# Altium schematic sheet GPS_GNSS.SchDoc(GPS_GNSS)
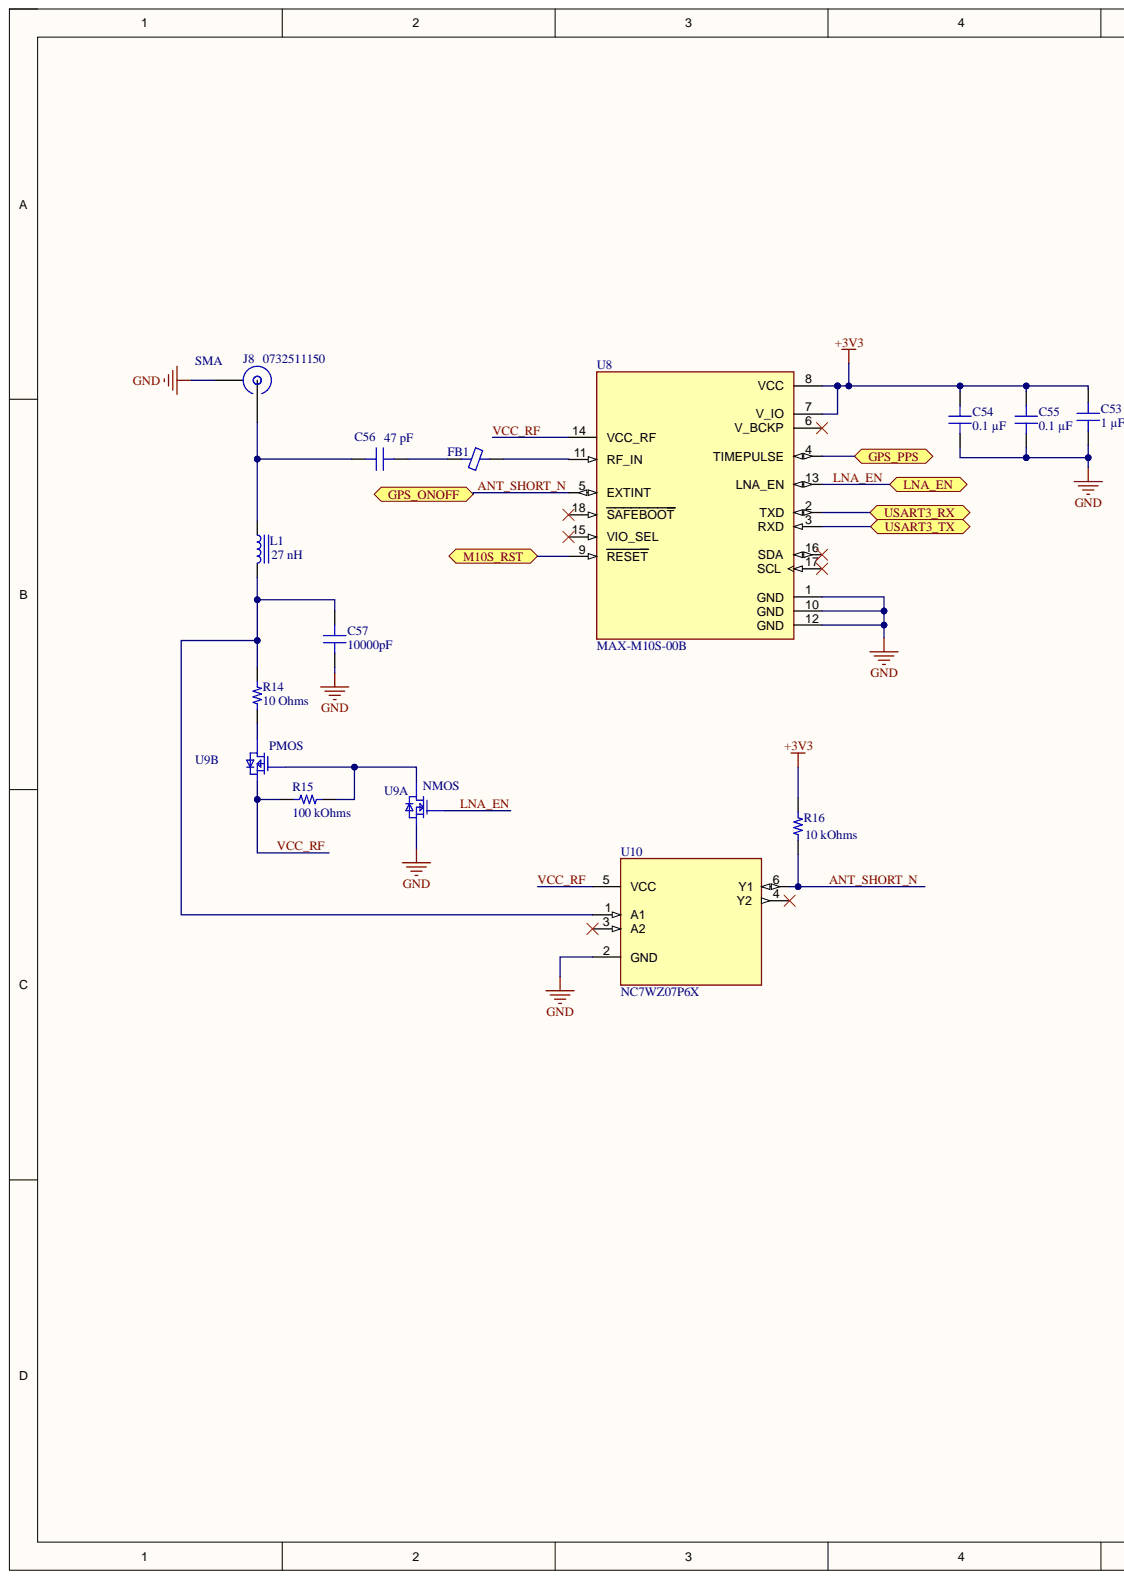
[diagram p0: GPS_GNSS.SchDoc, left]
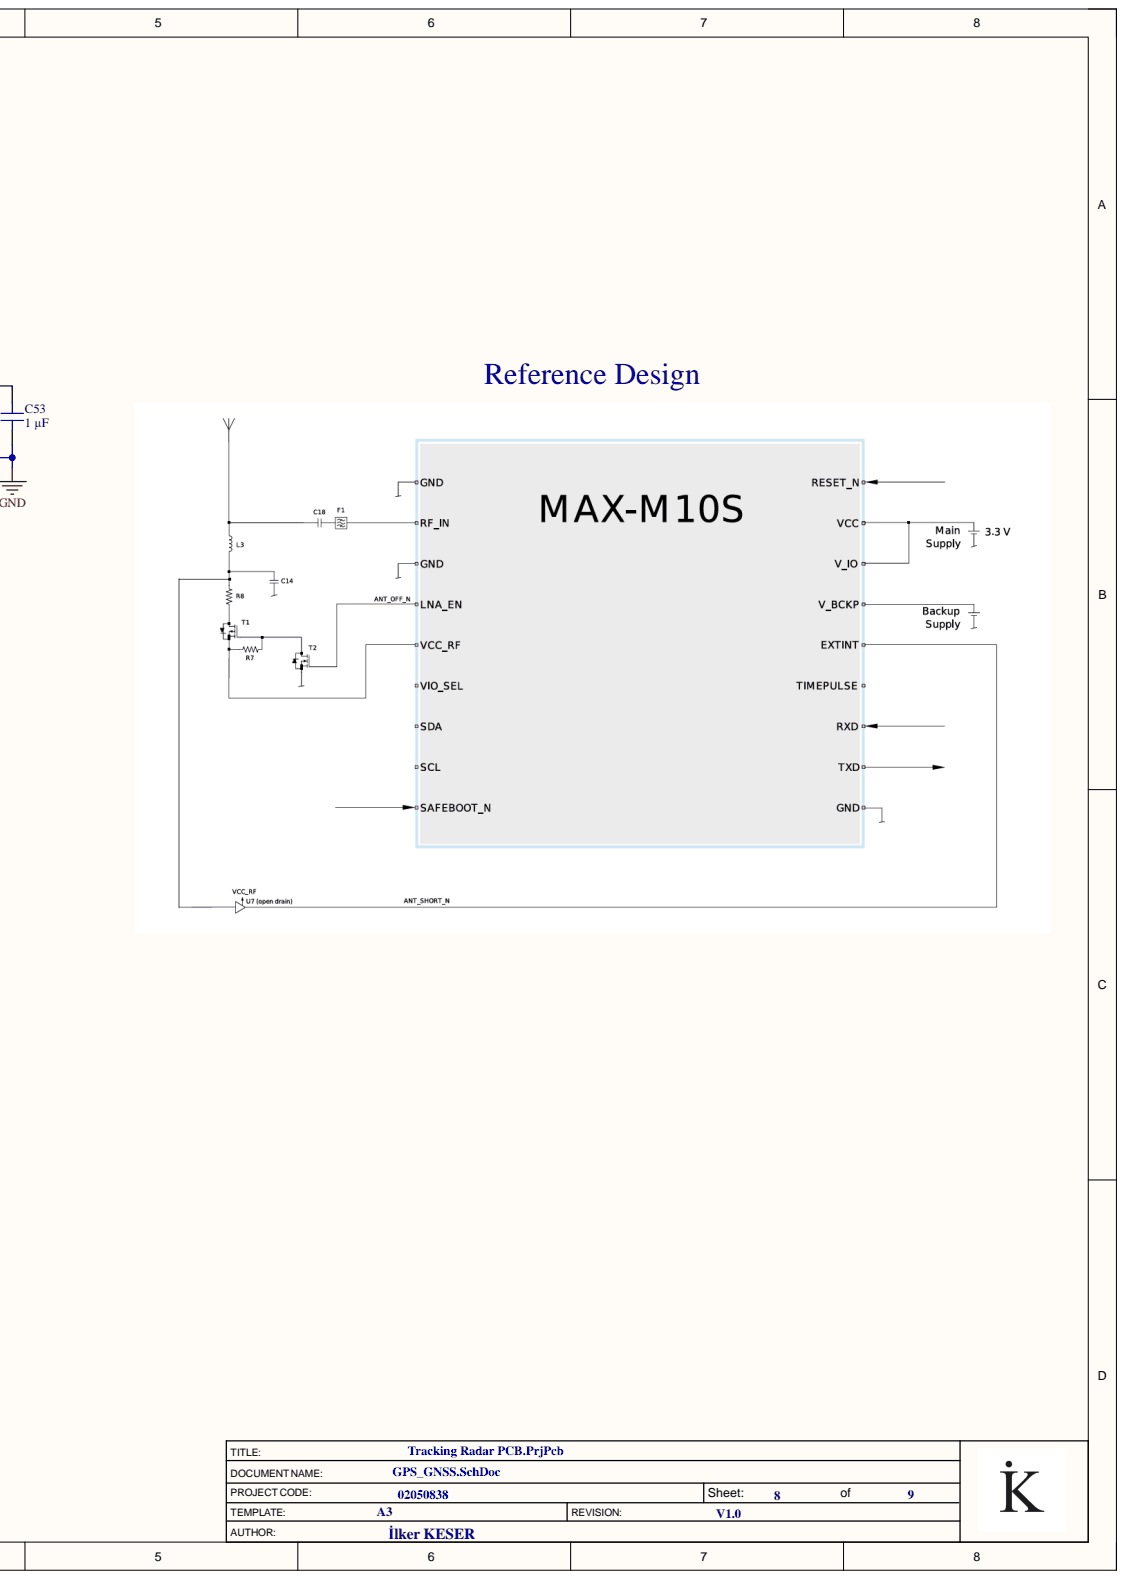
[diagram p1: GPS_GNSS.SchDoc, right]

## Parts
C53  1 µF  [MURATA GRM155 0402_1005]
C54  0.1 µF  [CAP 0402_1005]
C55  0.1 µF  [CAP 0402_1005]
C56  47 pF  [CAP 0402_1005]
C57  10000pF  [CAP 0603_1608 RF]
FB1  1 kOhms @ 100 MHz  [FER 0402_1005]  (value not drawn)
J8  0732511150  Connector Style: SMA  [MOLEX SD-73251-115]
L1  27 nH  [Murata LQG15 0402]
R14  10 Ohms  [YAGEO RES 0402_1005]
R15  100 kOhms  [YAGEO RES 0603_1608]
R16  10 kOhms  [KOA RES RK73 RN73 0402_1005]
U8  MAX-M10S-00B  [MAX-M10S-00B]
U9  DMC2700UDM-7  [SOT-23-6]  (value not drawn)  (parts A,B)
U10  NC7WZ07P6X  [NC7WZ07P6X]

## Ports
GPS_ONOFF, GPS_PPS, LNA_EN, M10S_RST, USART3_RX, USART3_TX

## Nets
+3V3: C53.2 C54.2 C55.2 R16.1 U8.7(V_IO) U8.8(VCC)
GND: C53.1 C54.1 C55.1 C57.2 J8.G U8.1(GND) U8.10(GND) U8.12(GND) U9.5 U10.2(GND)
ANT_SHORT_N: R16.2 U8.5(EXTINT) U10.6(Y1) ; port GPS_ONOFF
GPS_PPS: U8.4(TIMEPULSE) ; port GPS_PPS
LNA_EN: U8.13(LNA_EN) U9.1 ; port LNA_EN
M10S_RST: U8.9(RESET) ; port M10S_RST
USART3_RX: U8.2(TXD) ; port USART3_RX
USART3_TX: U8.3(RXD) ; port USART3_TX
VCC_RF: R15.1 U8.14(VCC_RF) U9.2 U10.5(VCC)
NetC56_1: C56.1 J8.S L1.1
NetC56_2: C56.2 FB1.1
NetC57_1: C57.1 L1.2 R14.2 U10.1(A1)
NetFB1_2: FB1.2 U8.11(RF_IN)
NetR14_1: R14.1 U9.4
NetR15_2: R15.2 U9.3 U9.6

Not connected: U8 6(V_BCKP) 15(VIO_SEL) 16(SDA) 17(SCL) 18(SAFEBOOT); U10 3(A2) 4(Y2)

## Library data of the parts
C53 = GRM155Z71A105KE01J: CAP CER 1UF 10V X7R 0402; manufacturer: Murata Electronics; Database Table Name: Capacitors - Ceramic - 0402; Tolerance: ±10%; Applications: General Purpose; Capacitance: 1 µF; Component Kind: Standard; componentlink1description: Datasheet; componentlink1url: https://search.murata.co.jp/Ceramy/image/img/A01X/G101/ENG/GRM155Z71A105KE01-01A.pdf; componentlink2description: DigiKey Link; componentlink2url: https://www.digikey.com/en/products/detail/murata-electronics/GRM155Z71A105KE01J/16033607; Device Package: 0402 (1005 Metric); lastupdated: 27.08.2024 12:42:50; Library Name: Celestial Altium Library - altium_library.DbLib; Lifecycle Status: Active; Minimum Order: 1; Mounting Type: Surface Mount, MLCC; Operating Temperature: -55°C ~ 125°C; Package / Case: 0402 (1005 Metric); packaging: TapeAndReel; Part Number: GRM155Z71A105KE01J; Part Status: Active; partid: 41629; price: 0.01; series: GRM; Size / Dimension: 0.039" L x 0.020" W (1.00mm x 0.50mm); Supplier 1: DigiKey; Supplier Part Number 1: 490-GRM155Z71A105KE01JCT-ND; Temperature Coefficient: X7R; Thickness (Max): 0.024" (0.60mm); value: 1 µF; Voltage - Rated: 10V
C54 C55 = 885012205018: CAP CER 0.1UF 10V X7R 0402; manufacturer: Würth Elektronik; Database Table Name: Capacitors - Ceramic - 0402; Tolerance: ±10%; Applications: General Purpose; Capacitance: 0.1 µF; Component Kind: Standard; componentlink1description: Datasheet; componentlink1url: https://katalog.we-online.de/pbs/datasheet/885012205018.pdf; componentlink2description: DigiKey Link; componentlink2url: https://www.digikey.com/en/products/detail/würth-elektronik/885012205018/5453368; Device Package: 0402 (1005 Metric); lastupdated: 9.02.2024 20:04:24; Library Name: Celestial Altium Library - altium_library.DbLib; Lifecycle Status: Active; Minimum Order: 1; Mounting Type: Surface Mount, MLCC; Operating Temperature: -55°C ~ 125°C; Package / Case: 0402 (1005 Metric); packaging: TapeAndReel; Part Number: 885012205018; Part Status: Active; partid: 10108; price: 0.01; series: WCAP-CSGP; Size / Dimension: 0.039" L x 0.020" W (1.00mm x 0.50mm); Supplier 1: DigiKey; Supplier Part Number 1: 732-7513-1-ND; Temperature Coefficient: X7R; Thickness (Max): 0.022" (0.55mm); value: 0.1 µF; Voltage - Rated: 10V
C56 = C0402C470J3GACAUTO: CAP CER 47PF 25V C0G/NP0 0402; manufacturer: KEMET; Database Table Name: Capacitors - Ceramic - 0402; Temperature Coefficient: C0G, NP0; Tolerance: ±5%; Applications: Automotive; Capacitance: 47 pF; Component Kind: Standard; componentlink1description: Datasheet; componentlink1url: https://connect.kemet.com:7667/gateway/IntelliData-ComponentDocumentation/1.0/download/datasheet/C0402C470J3GACAUTO; componentlink2description: DigiKey Link; componentlink2url: https://www.digikey.com/en/products/detail/kemet/C0402C470J3GACAUTO/10642318; Device Package: 0402 (1005 Metric); Features: Low ESL; lastupdated: 9.02.2024 20:04:06; Library Name: Celestial Altium Library - altium_library.DbLib; Lifecycle Status: Active; Minimum Order: 1; Mounting Type: Surface Mount, MLCC; Operating Temperature: -55°C ~ 125°C; Package / Case: 0402 (1005 Metric); packaging: TapeAndReel; Part Number: C0402C470J3GACAUTO; Part Status: Active; partid: 47193; price: 0.01; Ratings: AEC-Q200; series: SMD Auto C0G; Size / Dimension: 0.039" L x 0.020" W (1.00mm x 0.50mm); Supplier 1: DigiKey; Supplier Part Number 1: 399-C0402C470J3GACAUTOCT-ND; Thickness (Max): 0.022" (0.55mm); value: 47 pF; Voltage - Rated: 25V
C57 = EMK107SD103KA-T: CAP CER 10000PF 16V 0603; manufacturer: Taiyo Yuden; Database Table Name: Capacitors - Ceramic - RF; Tolerance: ±10%; Applications: RF, Microwave, High Frequency; Capacitance: 10000pF; Component Kind: Standard; componentlink1description: Datasheet; componentlink1url: http://www.yuden.co.jp/productdata/catalog/mlcc07_e.pdf; componentlink2description: DigiKey Link; componentlink2url: http://digikey.com/product-detail/en/taiyo-yuden/EMK107SD103KA-T/587-1094-1-ND/930871; Device Package: 0603 (1608 Metric); Features: Low Distortion; lastupdated: 2.09.2020 01:17:15; Library Name: Celestial Altium Library - altium_library.DbLib; Lifecycle Status: Active; Minimum Order: 1; Mounting Type: Surface Mount, MLCC; Operating Temperature: -55°C ~ 125°C; Package / Case: 0603 (1608 Metric); packaging: TapeAndReel; Part Number: EMK107SD103KA-T; Part Status: Active; partid: 1412; price: 0.07; series: CFCAP™; Size / Dimension: 0.063" L x 0.031" W (1.60mm x 0.80mm); Supplier 1: DigiKey; Supplier Part Number 1: 587-1094-1-ND; Thickness (Max): 0.035" (0.90mm); value: 10000pF; Voltage - Rated: 16V
FB1 = BLM15AG102SN1D: FERRITE BEAD 1K OHM 0402 1LN; Manufacturer: Murata Electronics; Current Rating (Max): 300mA; Database Table Name: Filters - Ferrite Beads and Chips; DC Resistance (DCR) (Max): 650mOhm; Number of Lines: 1; Component Kind: Standard; ComponentLink1Description: Datasheet; ComponentLink1URL: https://www.murata.com/en-us/products/productdata/8796740059166/ENFA0018.pdf; ComponentLink2Description: DigiKey Link; ComponentLink2URL: https://www.digikey.com/en/products/detail/murata-electronics/BLM15AG102SN1D/584218; Device Package: 0402 (1005 Metric); Height (Max): 0.022" (0.55mm); LastUpdated: 3.06.2024 19:51:04; Library Name: Celestial Altium Library - altium_library.DbLib; Lifecycle Status: Active; Minimum Order: 1; Mounting Type: Surface Mount; Operating Temperature: -55°C ~ 125°C; Package / Case: 0402 (1005 Metric); Packaging: TapeAndReel; Part Number: BLM15AG102SN1D; Part Status: Active; PartId: 387; Price: 0.01; Series: EMIFIL®, BLM15; Size / Dimension: 0.039" L x 0.022" W (1.00mm x 0.55mm); Supplier 1: DigiKey; Supplier Part Number 1: 490-1007-1-ND; Value: 1 kOhms @ 100 MHz; Impedance @ Frequency: 1 kOhms @ 100 MHz
J8 = 0732511150: CONN SMA RCPT STR 50OHM EDGE MNT; Manufacturer: Molex; Connector Type: Receptacle, Female Socket; Contact Termination: Solder; Database Table Name: Connectors - Coaxial RF; Frequency - Max: 18 GHz; Number of Ports: 1; Component Kind: Standard; ComponentLink1Description: Datasheet; ComponentLink1URL: https://www.molex.com/pdm_docs/ps/PS-89675-346.pdf; ComponentLink2Description: DigiKey Link; ComponentLink2URL: https://www.digikey.com/en/products/detail/molex/0732511150/1465156; Fastening Type: Threaded; Housing Color: Gold; LastUpdated: 29.06.2022 18:06:18; Library Name: Celestial Altium Library - altium_library.DbLib; Lifecycle Status: Active; Minimum Order: 1; Mounting Type: Board Edge, End Launch; Packaging: Tray; Part Number: 0732511150; Part Status: Active; Price: 2.16; Series: 73251; Shield Termination: Solder; Supplier 1: DigiKey; Supplier Part Number 1: WM5534-ND; Impedance: 50Ohm
L1 = LQG15HZ27NJ02D: FIXED IND 27NH 350MA 0.46OHM SMD; Manufacturer: Murata Electronics; Database Table Name: Inductors - RF; DC Resistance (DCR): 460mOhm Max; Frequency - Self Resonant: 1.7GHz; Material - Core: Air; Q @ Freq: 8 @ 100MHz; Shielding: Unshielded; Tolerance: ±5%; Type: Multilayer; Inductance Frequency - Test: 100 MHz; Component Kind: Standard; ComponentLink1Description: Datasheet; ComponentLink1URL: https://search.murata.co.jp/Ceramy/image/img/P02/JELF243B-9110.pdf; ComponentLink2Description: DigiKey Link; ComponentLink2URL: https://www.digikey.com/en/products/detail/murata-electronics/LQG15HZ27NJ02D/8323902; Current Rating (Amps): 350 mA; Device Package: 0402 (1005 Metric); Height - Seated (Max): 0.022" (0.55mm); LastUpdated: 19.07.2024 23:59:46; Library Name: Celestial Altium Library - altium_library.DbLib; Lifecycle Status: Active; Minimum Order: 1; Mounting Type: Surface Mount; Operating Temperature: -55°C ~ 125°C; Package / Case: 0402 (1005 Metric); Packaging: TapeAndReel; Part Number: LQG15HZ27NJ02D; Part Status: Active; PartId: 2424; Price: 0.02; Ratings: AEC-Q200; Series: LQG15; Size / Dimension: 0.039" L x 0.020" W (1.00mm x 0.50mm); Supplier 1: DigiKey; Supplier Device Package: 0402 (1005 Metric); Supplier Part Number 1: 490-LQG15HZ27NJ02DCT-ND; Value: 27 nH; Inductance: 27 nH
R14 = RC0402JR-0710RL: RES 10 OHM 5% 1/16W 0402; Manufacturer: Yageo; Database Table Name: Resistors - Surface Mount - 0402; Power (Watts): 0.063W, 1/16W; Temperature Coefficient: ±200ppm/°C; Component Kind: Standard; ComponentLink1Description: Datasheet; ComponentLink1URL: https://www.yageo.com/upload/media/product/productsearch/datasheet/rchip/PYu-RC_Group_51_RoHS_L_10.pdf; ComponentLink2Description: DigiKey Link; ComponentLink2URL: https://www.digikey.com/en/products/detail/yageo/RC0402JR-0710RL/726417; Composition: Thick Film; Device Package: 0402 (1005 Metric); Features: Moisture Resistant; Height - Seated (Max): 0.016" (0.40mm); LastUpdated: 25.07.2024 09:18:18; Library Name: Celestial Altium Library - altium_library.DbLib; Lifecycle Status: Active; Minimum Order: 1; Number of Terminations: 2; Operating Temperature: -55°C ~ 155°C; Package / Case: 0402 (1005 Metric); Packaging: CutTape; Part Number: RC0402JR-0710RL; Part Status: Active; PartId: 3405; Price: 0.01; Resistance: 10 Ohms; Series: RC_L; Size / Dimension: 0.039" L x 0.020" W (1.00mm x 0.50mm); Supplier 1: DigiKey; Supplier Device Package: 0402; Supplier Part Number 1: 311-10JRCT-ND; Tolerance: ±5%; Value: 10 Ohms
R15 = AA0603FR-07100KL: RES SMD 100K OHM 1% 1/10W 0603; Manufacturer: Yageo; Database Table Name: Resistors - Surface Mount - 0603; Power (Watts): 0.1W, 1/10W; Temperature Coefficient: ±150ppm/°C; Component Kind: Standard; ComponentLink1Description: Datasheet; ComponentLink1URL: https://www.yageo.com/upload/media/product/productsearch/datasheet/rchip/PYu-AA_51_RoHS_L_3.pdf; ComponentLink2Description: DigiKey Link; ComponentLink2URL: https://www.digikey.com/en/products/detail/yageo/AA0603FR-07100KL/5888687; Composition: Thick Film; Device Package: 0603 (1608 Metric); Features: Anti-Sulfur, Automotive AEC-Q200, Moisture Resistant; Height - Seated (Max): 0.022" (0.55mm); LastUpdated: 25.07.2024 09:19:03; Library Name: Celestial Altium Library - altium_library.DbLib; Lifecycle Status: Active; Minimum Order: 1; Number of Terminations: 2; Operating Temperature: -55°C ~ 155°C; Package / Case: 0603 (1608 Metric); Packaging: TapeAndReel; Part Number: AA0603FR-07100KL; PartId: 78895; Price: 0.01; Ratings: AEC-Q200; Resistance: 100 kOhms; Series: AA; Size / Dimension: 0.063" L x 0.031" W (1.60mm x 0.80mm); Supplier 1: DigiKey; Supplier Device Package: 0603; Supplier Part Number 1: 13-AA0603FR-07100KLCT-ND; Tolerance: ±1%; Value: 100 kOhms
R16 = RK73H1ETTP1002D: RES 10K OHM 0.5% 1/10W 0402; Manufacturer: KOA Speer Electronics, Inc.; Database Table Name: Resistors - Surface Mount - 0402; Power (Watts): 0.1W, 1/10W; Temperature Coefficient: ±100ppm/°C; Component Kind: Standard; ComponentLink1Description: Datasheet; ComponentLink1URL: http://www.koaspeer.com/catimages/Products/RK73H/RK73H.pdf; ComponentLink2Description: DigiKey Link; ComponentLink2URL: https://www.digikey.com/en/products/detail/koa-speer-electronics-inc/RK73H1ETTP1002D/9844692; Composition: Thick Film; Device Package: 0402 (1005 Metric); Features: Automotive AEC-Q200; Height - Seated (Max): 0.016" (0.40mm); LastUpdated: 25.07.2024 09:41:31; Library Name: Celestial Altium Library - altium_library.DbLib; Lifecycle Status: Active; Minimum Order: 1; Number of Terminations: 2; Operating Temperature: -55°C ~ 155°C; Package / Case: 0402 (1005 Metric); Packaging: TapeAndReel; Part Number: RK73H1ETTP1002D; Part Status: Active; PartId: 14647; Price: 0.03; Ratings: AEC-Q200; Resistance: 10 kOhms; Series: RK73H; Size / Dimension: 0.039" L x 0.020" W (1.00mm x 0.50mm); Supplier 1: DigiKey; Supplier Device Package: 0402; Supplier Part Number 1: 2019-RK73H1ETTP1002DCT-ND; Tolerance: ±0.5%; Value: 10 kOhms
U8 = MAX-M10S-00B: MAX-M10 RF Receiver BeiDou, Galileo, GLONASS, GPS, GNSS 1.561GHz, 1.575GHz, 1.602GHz -167dBm 921.6kbps Not Included Module; Manufacturer: ublox; Data Interface: I2C,UART; Voltage - Supply: 1.76V ~ 3.6V; Antenna Connector: Not Included; Applications: GPS/GNSS Receiver; Component Kind: Standard; ComponentLink1Description: Datasheet; ComponentLink1URL: https://content.u-blox.com/sites/default/files/MAX-M10S_DataSheet_UBX-20035208.pdf; ComponentLink2Description: Digikey Link; ComponentLink2URL: https://www.digikey.com/en/products/detail/u-blox/max-m10s-00b/15712906; Data Rate (Max): 921.6kbps; Frequency: 1.561GHz, 1.575GHz, 1.602GHz; Library Name: PCB_Lib.IntLib; Modulation or Protocol: BeiDou, Galileo, GLONASS, GPS, GNSS; Mounting Type: Surface Mount; Operating Temperature: -40°C ~ 85°C; Sensitivity: -167dBm
U9 = DMC2700UDM-7: Mosfet Array 20V 1.34A, 1.14A 1.12W Surface Mount SOT-26; Manufacturer: Diodes Incorporated; Configuration: N and P-Channel; FET Feature: Logic Level Gate; Gate Charge (Qg) (Max) @ Vgs: 0.74nC @ 4.5V; Rds On (Max) @ Id, Vgs: 400mOhm @ 600mA, 4.5V; Vgs(th) (Max) @ Id: 1V @ 250µA; Input Capacitance (Ciss) (Max) @ Vds: 60.67pF @ 16V; Component Kind: Standard; ComponentLink1Description: Datasheet; ComponentLink1URL: https://www.diodes.com/assets/Datasheets/DMC2700UDM.pdf; ComponentLink2Description: Digikey Link; ComponentLink2URL: https://www.digikey.com/en/products/detail/diodes-incorporated/DMC2700UDM-7/2765657; Current - Continuous Drain (Id) @ 25°C: 1.34A, 1.14A; Drain to Source Voltage (Vdss): 20V; Library Name: PCB_Lib.IntLib; Operating Temperature: -55°C ~ 150°C (TJ); Package / Case: SOT-23-6; Power - Max: 1.12W; Technology: MOSFET (Metal Oxide)
U10 = NC7WZ07P6X: Buffer, Non-Inverting 2 Element 1 Bit per Element Open Drain Output SC-88 (SC-70-6); Manufacturer: onsemi; Current - Output High, Low: -, 32mA; Number of Bits per Element: 1; Number of Elements: 2; Voltage - Supply: 1.65V ~ 5.5V; Component Kind: Standard; ComponentLink1Description: Datasheet; ComponentLink1URL: https://www.onsemi.com/pdf/datasheet/nc7wz07-d.pdf; ComponentLink2Description: Digikey link; ComponentLink2URL: https://www.digikey.com/en/products/detail/onsemi/nc7wz07p6x/965440; Library Name: PCB_Lib.IntLib; Logic Type: Buffer, Non-Inverting; Mounting Type: Surface Mount; Operating Temperature: -40°C ~ 85°C (TA); Output Type: Open Drain; Package / Case: 6-TSSOP, SC-88, SOT-363; Supplier Device Package: SC-88 (SC-70-6)
